# Altium schematic sheet MFRC522_Reader.SchDoc
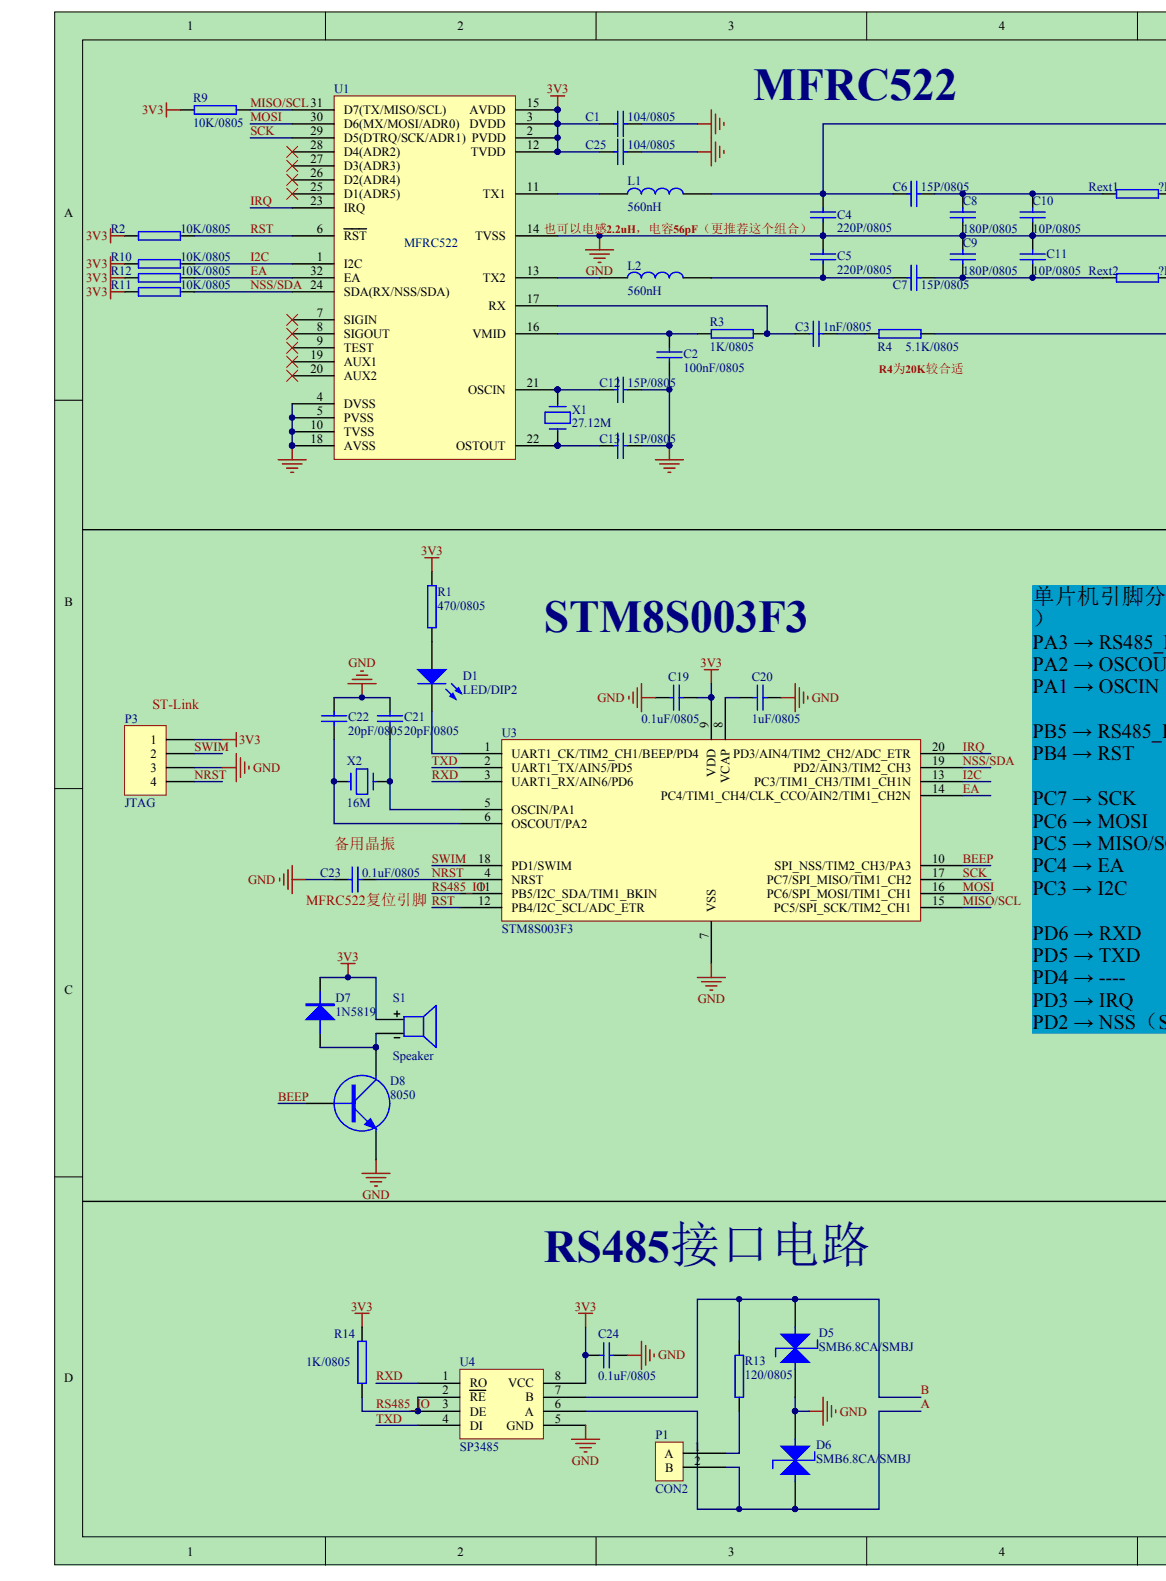
[diagram p0: MFRC522_Reader.SchDoc, left]
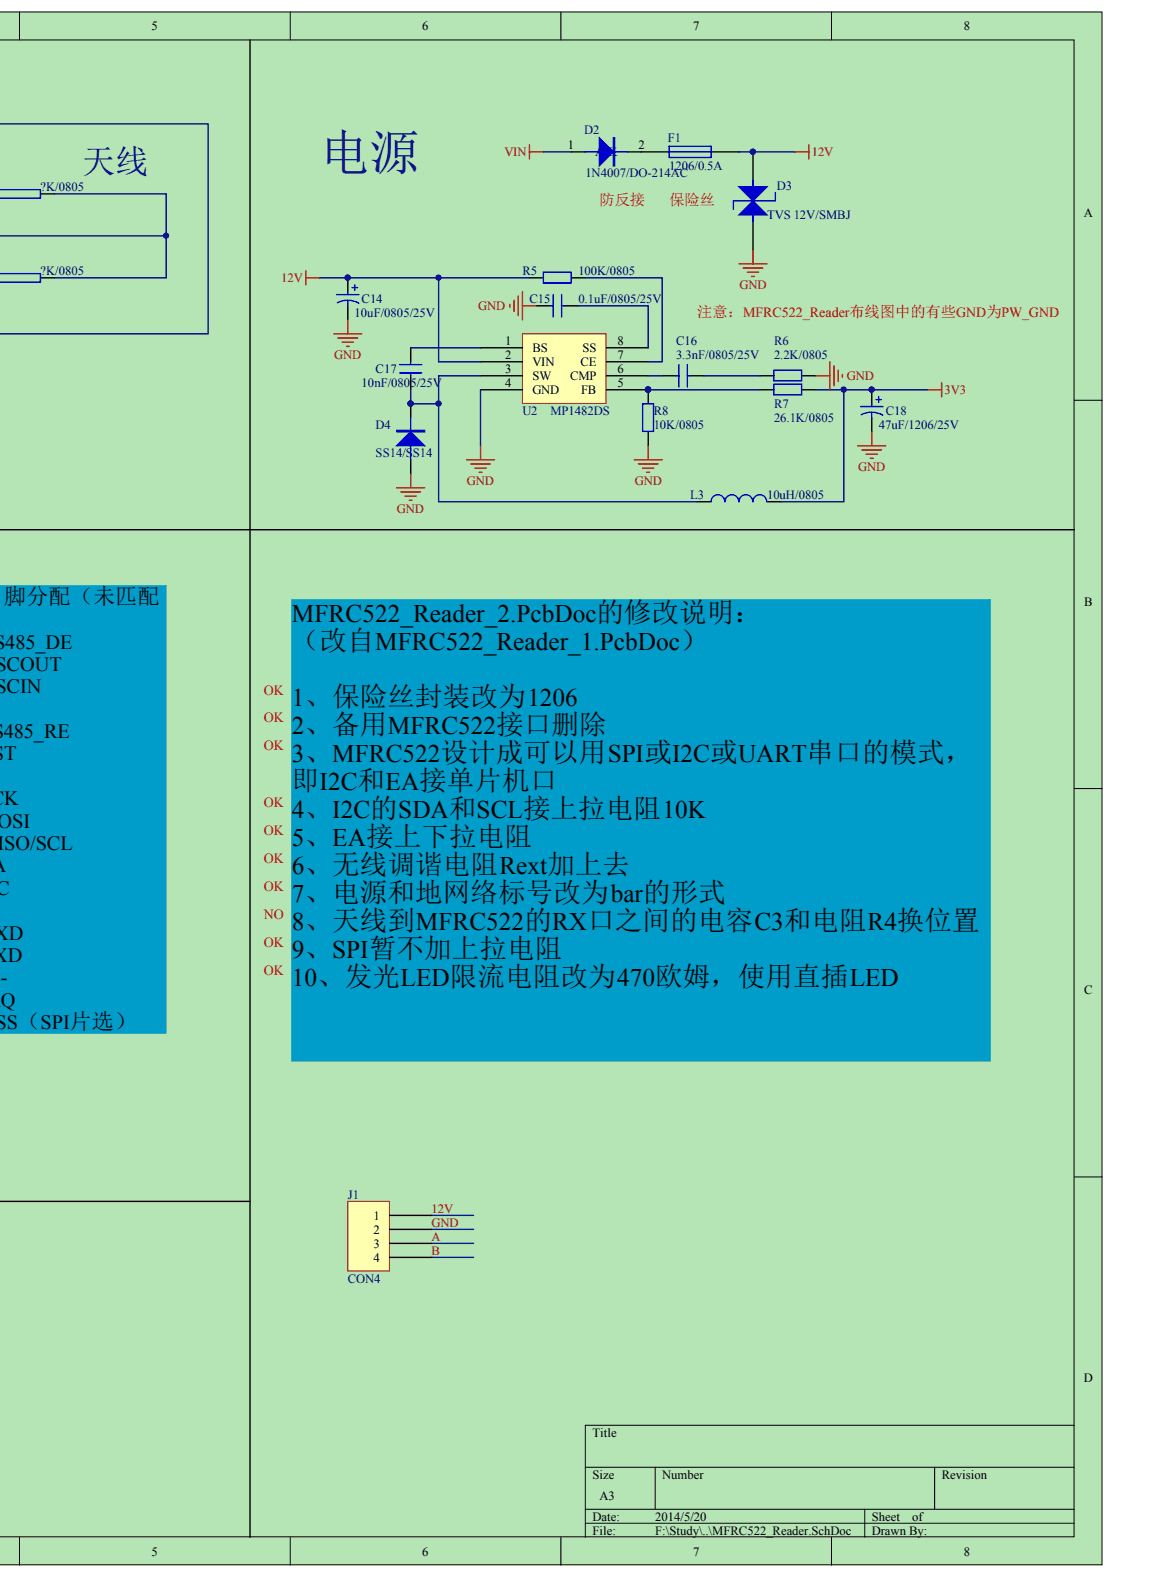
[diagram p1: MFRC522_Reader.SchDoc, right]

## Parts
C1  104/0805
C2  100nF/0805
C3  1nF/0805
C4  220P/0805
C5  220P/0805
C6  15P/0805
C7  15P/0805
C8  180P/0805
C9  180P/0805
C10  10P/0805
C11  10P/0805
C12  15P/0805
C13  15P/0805
C14  型号: 10uF/0805/25V  (hidden comment: 有极性电容)
C15  型号: 0.1uF/0805/25V  (hidden comment: 无极性电容)
C16  型号: 3.3nF/0805/25V  (hidden comment: 无极性电容)
C17  型号: 10nF/0805/25V  (hidden comment: 无极性电容)
C18  型号: 47uF/1206/25V  (hidden comment: 有极性电容)
C19  0.1uF/0805
C20  1uF/0805
C21  20pF/0805
C22  20pF/0805
C23  0.1uF/0805
C24  0.1uF/0805
C25  104/0805
D1  LED/DIP2
D2  1N4007/DO-214AC
D3  TVS 12V/SMBJ
D4  SS14/SS14
D5  SMB6.8CA/SMBJ
D6  SMB6.8CA/SMBJ
D7  1N5819
D8  8050
F1  保险丝  型号: 1206/0.5A
J1  CON4
L1  560nH
L2  560nH
L3  型号: 10uH/0805  (hidden comment: 电感)
P1  CON2
P3  JTAG
R1  470/0805
R2  10K/0805
R3  1K/0805
R4  5.1K/0805
R5  型号: 100K/0805  (hidden comment: 电阻)
R6  型号: 2.2K/0805  (hidden comment: 电阻)
R7  型号: 26.1K/0805  (hidden comment: 电阻)
R8  型号: 10K/0805  (hidden comment: 电阻)
R9  10K/0805
R10  10K/0805
R11  10K/0805
R12  10K/0805
R13  120/0805
R14  1K/0805
Rext1  ?K/0805
Rext2  ?K/0805
S1  Speaker
U1  MFRC522
U2  型号: MP1482DS  (hidden comment: 电源芯片)
U3  STM8S003F3
U4  SP3485
X1  27.12M
X2  16M

## Nets
3V3: C1.1 C18.1 C19.1 C24.1 C25.1 D7.2 L3.2 P3.1 R1.2 R2.2 R7.1 R9.2 R10.2 R11.2 R12.2 R14.2 S1.1 U1.2(PVDD) U1.3(DVDD) U1.12(TVDD) U1.15(AVDD) U3.9(VDD) U4.8(VCC)
VIN: D2.1
12V: C14.1 D3.2 F1.2 J1.1 R5.2 U2.2(VIN)
A: D6.2 J1.3 P1.2 U4.6(A)
B: D5.2 J1.4 R13.2 U4.7(B)
BEEP: D8.1 U3.10(SPI_NSS/TIM2_CH3/PA3)
EA: R12.1 U1.32(EA) U3.14(PC4/TIM1_CH4/CLK_CCO/AIN2/TIM1_CH2N)
GND: C1.2 C2.2 C4.1 C5.1 C8.2 C9.1 C10.2 C11.1 C12.2 C13.2 C14.2 C15.1 C18.2 C19.2 C20.2 C21.2 C22.2 C23.2 C24.2 C25.2 D3.1 D4.1 D5.1 D6.1 D8.2 J1.2 P3.3 R6.1 R8.2 Rext1.2 Rext2.2 U1.4(DVSS) U1.5(PVSS) U1.10(TVSS) U1.14(TVSS) U1.18(AVSS) U2.4(GND) U3.7(VSS) U4.5(GND)
I2C: R10.1 U1.1(I2C) U3.13(PC3/TIM1_CH3/TIM1_CH1N)
IRQ: U1.23(IRQ) U3.20(PD3/AIN4/TIM2_CH2/ADC_ETR)
MISO/SCL: R9.1 U1.31(D7(TX/MISO/SCL)) U3.15(PC5/SPI_SCK/TIM2_CH1)
MOSI: U1.30(D6(MX/MOSI/ADR0)) U3.16(PC6/SPI_MOSI/TIM1_CH1)
NRST: C23.1 P3.4 U3.4(NRST)
NSS/SDA: R11.1 U1.24(SDA(RX/NSS/SDA)) U3.19(PD2/AIN3/TIM2_CH3)
RS485_IO: R14.1 U3.11(PB5/I2C_SDA/TIM1_BKIN) U4.2(RE) U4.3(DE)
RST: R2.1 U1.6(RST) U3.12(PB4/I2C_SCL/ADC_ETR)
RXD: U3.3(UART1_RX/AIN6/PD6) U4.1(RO)
SCK: U1.29(D5(DTRQ/SCK/ADR1)) U3.17(PC7/SPI_MISO/TIM1_CH2)
SWIM: P3.2 U3.18(PD1/SWIM)
TXD: U3.2(UART1_TX/AIN5/PD5) U4.4(DI)
NetC2_1: C2.1 R3.1 U1.16(VMID)
NetC3_1: C3.1 R3.2 U1.17(RX)
NetC3_2: C3.2 R4.1
NetC4_2: C4.2 C6.2 L1.2 R4.2
NetC5_2: C5.2 C7.2 L2.2
NetC6_1: C6.1 C8.1 C10.1 Rext1.1
NetC7_1: C7.1 C9.2 C11.2 Rext2.1
NetC12_1: C12.1 U1.21(OSCIN) X1.2
NetC13_1: C13.1 U1.22(OSTOUT) X1.1
NetC15_2: C15.2 U2.8(SS)
NetC16_1: C16.1 U2.6(CMP)
NetC16_2: C16.2 R6.2
NetC17_1: C17.1 D4.2 L3.1 U2.3(SW)
NetC17_2: C17.2 U2.1(BS)
NetC20_1: C20.1 U3.8(VCAP)
NetC21_1: C21.1 U3.5(OSCIN/PA1) X2.2
NetC22_1: C22.1 U3.6(OSCOUT/PA2) X2.1
NetD1_1: D1.1 R1.1
NetD1_2: D1.2 U3.1(UART1_CK/TIM2_CH1/BEEP/PD4)
NetD2_2: D2.2 F1.1
NetD7_1: D7.1 D8.3 S1.2
NetL1_1: L1.1 U1.11(TX1)
NetL2_1: L2.1 U1.13(TX2)
NetP1_1: P1.1 R13.1
NetR5_1: R5.1 U2.7(CE)
NetR7_2: R7.2 R8.1 U2.5(FB)

Not connected: U1 7(SIGIN) 8(SIGOUT) 9(TEST) 19(AUX1) 20(AUX2) 25(D1(ADR5)) 26(D2(ADR4)) 27(D3(ADR3)) 28(D4(ADR2))

## Library data of the parts
L3 = (no symbol name): Code_IEC: 1005; Published: 8-Jun-2000; LatestRevisionDate: 29-May-2009; LatestRevisionNote: IPC-7351 Footprint Added.; PackageReference: 0402-A; PackageDescription: Chip Inductor; Code_JEITA: 0402; Publisher: Altium Limited
C1-C25 D1-D8 F1 J1 L1 L2 P1 P3 R1-R14 Rext1 Rext2 S1 U1-U4 X1 X2 = (no symbol name)
  C19 C23 C24: Value: 0.1uF/0805
  C20: Value: 1uF/0805
  C21 C22: Value: 20pF/0805
  F1: Published: 8-Jun-2000; LatestRevisionDate: 17-Jul-2002; LatestRevisionNote: Re-released for DXP Platform.; PackageReference: PIN-W2/E2.8; PackageDescription: Thru-Hole; 2 Leads
  P3: LatestRevisionDate: 17-Jul-2002; LatestRevisionNote: Re-released for DXP Platform.
  R5-R8: Published: 8-Jun-2000; LatestRevisionDate: 17-Jul-2002; LatestRevisionNote: Re-released for DXP Platform.; PackageReference: AXIAL-0.4
  F1 P3 R5-R8: Publisher: Altium Limited
